# Altium schematic sheet PWR.SchDoc(PWR)
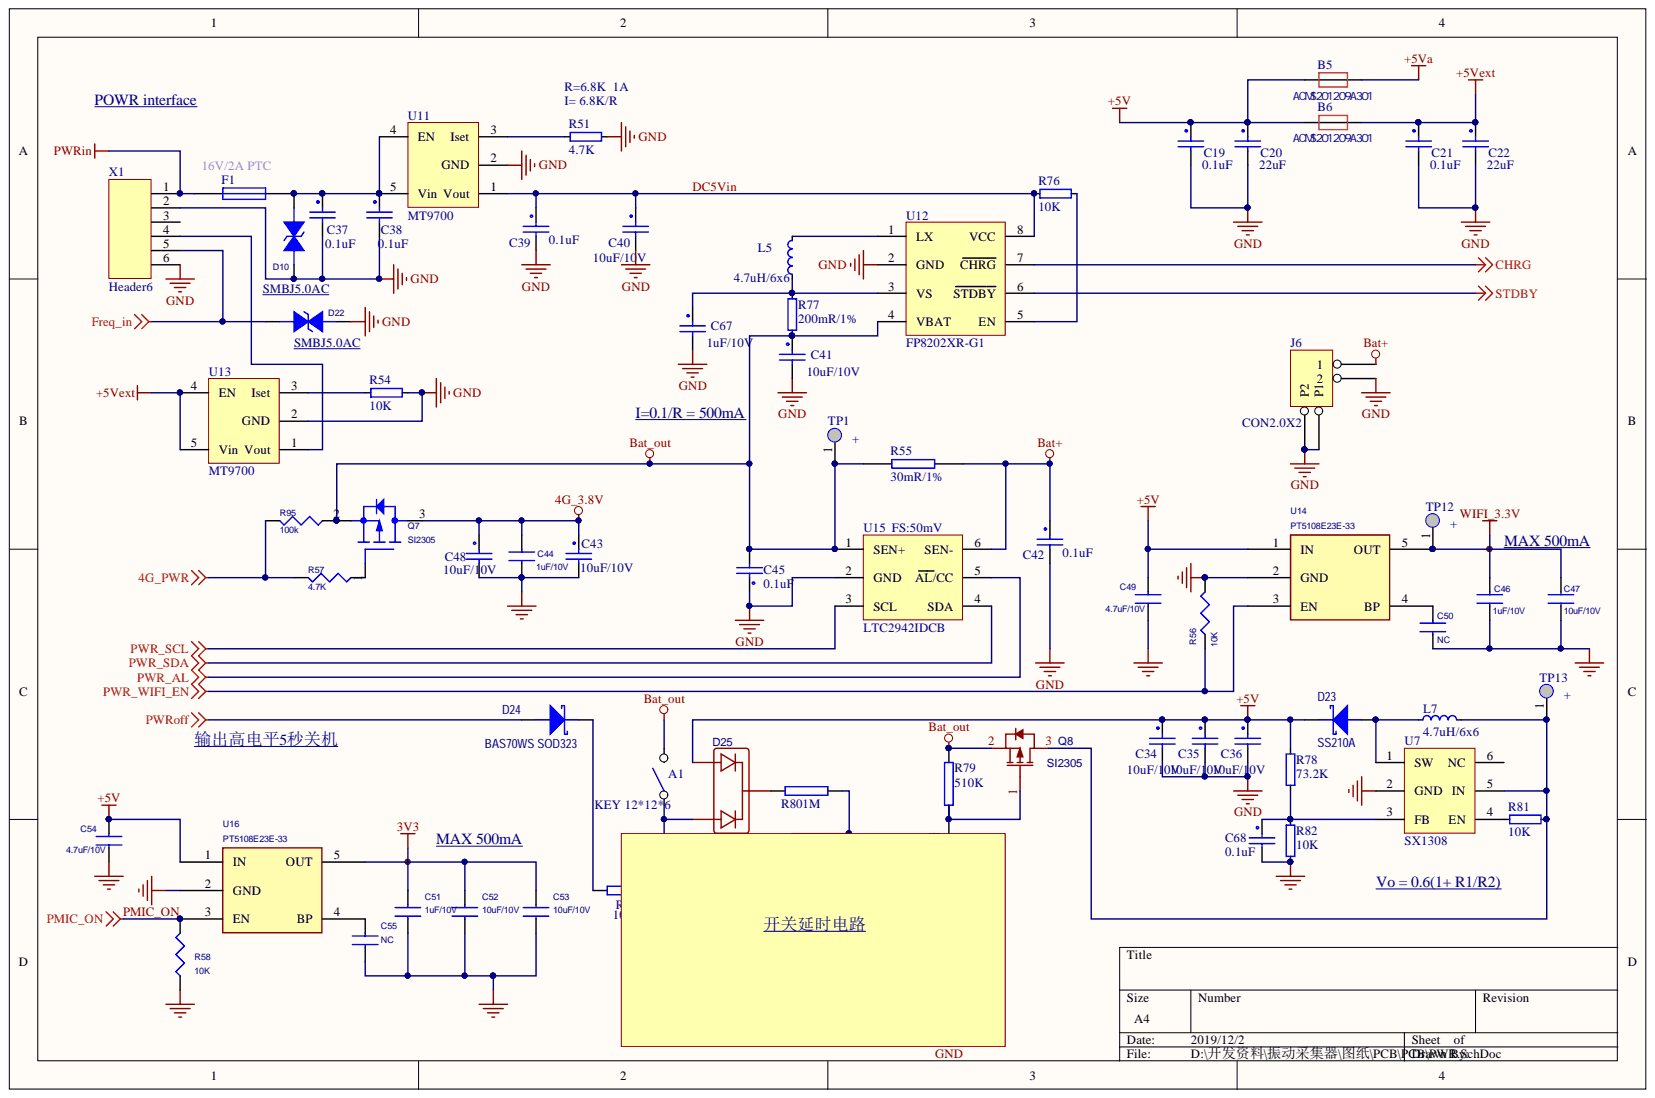
[diagram p0: PWR.SchDoc]

## Parts
A1  KEY 12*12*6  [BT12x12*6]
B5  ACMS201209A301  [0805_R]
B6  ACMS201209A301  [0805_R]
C19  0.1uF  [0402_C]
C20  22uF  [0603_C]
C21  0.1uF  [0402_C]
C22  22uF  [0603_C]
C34  10uF/10V  [0603_C]
C35  10uF/10V  [0603_C]
C36  10uF/10V  [0603_C]
C37  0.1uF  [0402_C]
C38  0.1uF  [0402_C]
C39  0.1uF  [0402_C]
C40  10uF/10V  [0603_C]
C41  10uF/10V  [0603_C]
C42  0.1uF  [0402_C]
C43  10uF/10V  [0603_C]
C44  1uF/10V  [0402_C]
C45  0.1uF  [0402_C]
C46  1uF/10V  [0402_C]
C47  10uF/10V  [0603_C]
C48  10uF/10V  [0603_C]
C49  4.7uF/10V  [0402_C]
C50  NC  [0402_C]
C51  1uF/10V  [0402_C]
C52  10uF/10V  [0603_C]
C53  10uF/10V  [0603_C]
C54  4.7uF/10V  [0402_C]
C55  NC  [0402_C]
C67  1uF/10V  [0402_C]
C68  0.1uF  [0402_C]
C69  0.1uF  [0402_C]
C70  1uF/10V  [0402_C]
D10  SMBJ5.0AC  [DO214]
D22  SMBJ5.0AC  [DO214]
D23  SS210A  [DO214AA]
D24  BAS70WS SOD323  [SOD-323]
D25  BAS70W-05  [SOT-23]
F1  16V/2A PTC  [1812]
J6  CON2.0X2  [HY20LB-2P]
L5  4.7uH/6x6  [0402]
L7  4.7uH/6x6  [0402]
Q7  SI2305  [SOT23]
Q8  SI2305  [SOT-23]
Q9  8050  [SOT-23(SOT-23-3)]
Q10  8050  [SOT-23(SOT-23-3)]
R51  4.7K  [0402]
R53  1M  [0402]
R54  10K  [0402]
R55  30mR/1%  [0805_R]
R56  10K  [0402_R]
R57  4.7K  [0603_C]
R58  10K  [0402_R]
R76  10K  [0402]
R77  200mR/1%  [0402]
R78  73.2K  [0402]
R79  510K  [0402_R]
R80  1M  [0402_R]  (value not drawn)
R81  10K  [0402]
R82  10K  [0402]
R83  510K  [0402_R]
R84  100K  [0402_R]
R85  100K  [0402_R]
R86  100K  [0402_R]
R87  1M  [0402]
R95  100k  [0603_C]
TP1  +  [TP]
TP12  +  [TP]
TP13  +  [TP]
U7  SX1308
U11  MT9700  [SOT23-5]
U12  FP8202XR-G1  [SO-8-A]
U13  MT9700  [SOT23-5]
U14  PT5108E23E-33  [SOT23-5]
U15  LTC2942IDCB  [DFN-6]
U16  PT5108E23E-33  [SOT23-5]
X1  Header6  [ZH15LB-6P]

## Nets
3V3: C51.1 C52.1 C53.1 U16.5(OUT)
4G_3.8V: C43.1 C44.1 C48.1 Q7.3
4G_PWR: R57.1 R95.1
+5V: B5.1 B6.1 C19.1 C20.1 C34.1 C35.1 C36.1 C49.1 C54.1 D23.C D25.1 R78.1 U14.1(IN) U16.1(IN)
+5VA: B5.2
+5VEXT: B6.2 C21.1 C22.1 U13.4(EN) U13.5(Vin)
BAT+: C42.1 J6.1 R55.2 U15.6(SEN-)
BAT_OUT: A1.2 C41.1 C45.2 Q7.2 Q8.2 R55.1 R77.2 R79.1 R95.2 TP1.1 U12.4(VBAT) U15.1(SEN+)
CHRG: U12.7(CHRG)
FREQ_IN: D22.1 X1.5
GND: C19.2 C20.2 C21.2 C22.2 C34.2 C35.2 C36.2 C37.2 C38.2 C39.2 C40.2 C41.2 C42.2 C43.2 C44.2 C45.1 C46.2 C47.2 C48.2 C49.2 C50.1 C51.2 C52.2 C53.2 C54.2 C55.1 C67.2 C68.2 C69.2 C70.2 D10.2 D22.2 J6.2 J6.P1 J6.P2 R51.1 R53.1 R54.1 R56.2 R58.1 R82.1 R83.2 R86.2 U7.2(GND) U11.2(GND) U12.2(GND) U13.2(GND) U14.2(GND) U15.2(GND) U16.2(GND) X1.2 X1.6
PWR_AL: U15.5(AL/CC)
PWR_SCL: U15.3(SCL)
PWR_SDA: U15.4(SDA)
PWR_WIFI_EN: R56.1 U14.3(EN)
PWRIN: F1.2 X1.1
PWROFF: D24.A
STDBY: U12.6(STDBY)
WIFI_3.3V: C46.1 C47.1 TP12.1 U14.5(OUT)
DC5VIN: C39.1 C40.1 R76.2 U11.1(Vout) U12.8(VCC)
PMIC_ON: R58.2 U16.3(EN)
NetA1_1: A1.1 D25.2 R87.2
NetC37_1: C37.1 C38.1 D10.1 F1.1 U11.4(EN) U11.5(Vin)
NetC50_2: C50.2 U14.4(BP)
NetC55_2: C55.2 U16.4(BP)
NetC67_1: C67.1 L5.1 R77.1 U12.3(VS)
NetC68_1: C68.1 R78.2 R82.2 U7.3(FB)
NetC69_1: C69.1 Q9.1 Q10.3 R80.1 R83.1
NetC70_1: C70.1 R53.2 R84.1 R85.2 R87.1
NetD23_A: D23.A L7.1 U7.1(SW)
NetD24_C: D24.C R84.2
NetD25_3: D25.3 R80.2
NetL5_2: L5.2 U12.1(LX)
NetL7_2: L7.2 Q8.3 R81.1 TP13.1 U7.5(IN)
NetQ7_1: Q7.1 R57.2
NetQ8_1: Q8.1 Q9.3 R79.2
NetQ9_2: Q9.2 Q10.2 R86.1
NetQ10_1: Q10.1 R85.1
NetR51_2: R51.2 U11.3(Iset)
NetR54_2: R54.2 U13.3(Iset)
NetR76_1: R76.1 U12.5(EN)
NetR81_2: R81.2 U7.4(EN)
NetU13_1: U13.1(Vout) X1.4

Not connected: U7 6(NC); X1 3

## Library data of the parts
A1 = SW_SPST_6MM: SPST SWITCH 6MM; MANUFACTURER: TE CONNECTIVITY / ALCOSWITCH; PART: FSM4JSMA; Component Kind: Standard; FARNELL: 3801305; Library Name: Arbury_SCH.SchLib
B5 B6 = Bead: Component Kind: Standard; Library Name: RP3288BV03_20180126-.SCHLIB
C19 C21 C37-C39 C42 C45 C68 C69 = CAP_100N_25V_0402_10%_X5R: MANUFACTURER: TAIYO YUDEN; PART: TMK105BJ104KV-F; Component Kind: Standard; Description: 0.1uF; FARNELL: 1611916; Library Name: Arbury_C.SchLib
C20 C22 C34-C36 C40 C41 C43 C48 C67 C70 = CAP_100N_25V_0402_10%_X5R: 100nF; MANUFACTURER: TAIYO YUDEN; PART: TMK105BJ104KV-F; Component Kind: Standard; FARNELL: 1611916; Library Name: Arbury_C.SchLib
C44 = CAP NP_Dup2: CAP,MLCC,100nF,+/-10%,X7R,6.3V,SMD0402; Component Kind: Standard
C46 C47 C49 C51-C54 = CAP NP_Dup4: RoHS CHIP CAP 4.7uF +10/-10% X5R; Component Kind: Standard
C50 C55 = CAP NP_Dup4: RoHS CHIP CAP 0.1uF +10/-10% X7R; Component Kind: Standard
D10 D22 = DIODE BI-DIR TRIG: ESD; Component Kind: Standard
D23 D24 = DIO-SCH: Diode schottky; Component Kind: Standard; Library Name: Diodes_cn.SCHLIB
D25 = BAS70W-05: BOM_Manufacturer: CJ; Contributor: LCSC; SMT Type: Extend; BOM_LCSC Assembly: Yes; BOM_Manufacturer Part: BAS70W-05; BOM_Supplier: LCSC; BOM_Supplier Part: C77310; Component Kind: Standard; spicePre: D
F1 = FUSE1: Fuse; Component Kind: Standard
J6 = CON_JST_2MM_2W_SMD_H: 2 PIN 2MM SMT JST  CONNECTOR; PART: S2B-PH-SM4-TB(LF)(SN); Component Kind: Standard; DIGIKEY: 455-1749-1-ND; FARNELL: 9492615; MANUFACTURER: JST; Library Name: Arbury_CON.SchLib
L5 L7 = L0402-3n3: Inductor, 190mA, ?.1nH; Manufacturer: Murata; Manufacturer Part Number: LQP15MN3N3B02D; Artikkelnr Noca: N.A.; Database Table Name: Inductors$; Note: High frequency chip inductor ?%; Value: 3.3nH; Component Kind: Standard; Datasheet: http://search.murata.co.jp/Ceramy/image/img/PDF/ENG/L0075S0084LQP15M.pdf; LatestRevisionDate: 2012-09-19; Library Name: Nordic Passive DB.DbLib; Part Number: L0402-3n3; Pin Count: 2; Published: 2009-05-08; Supplier: Digi-Key; Supplier part number: 490-1130-1-ND; Publisher: Nordic Semiconductor
Q7 = FET P MOS: Component Kind: Standard
Q8 = SI2301_C351397: BOM_Manufacturer: PUOLOP; BOM_Manufacturer Part: SI2301; Contributor: LCSC; BOM_Supplier: LCSC; BOM_Supplier Part: C351397; Component Kind: Standard; spicePre: Q
Q9 Q10 = NPN: NPN Transistor; Component Kind: Standard
R51 R53 R54 R76-R78 R81 R82 R87 = R0402-12k: Resistor, ?%, 0.063W; Artikkelnr Noca: 27049099; Database Table Name: Resistors$; Note: Chip Res 1%; Value: 12k; Component Kind: Standard; LatestRevisionDate: 2009-11-23; Library Name: Nordic Passive DB.DbLib; Part Number: R0402-12k; Pin Count: 2; Published: 2009-05-08; Supplier: Farnell; Supplier part number: 173-8865; Publisher: Nordic Semiconductor
R55 R79 R80 R83-R86 = RES2: Component Kind: Standard
R56 R58 = RESISTOR_Dup2: ROHS CHIP RES 10K 1/16W 5%; Component Kind: Standard
R57 R95 = RESISTOR_1: RES,thick film,47KOhm,+/-5%,1/16W,SMD0402; Component Kind: Standard
TP1 TP12 TP13 = TP: Component Kind: Standard; Library Name: mysch.SchLib
U14 U16 = LDO-PT5108/RT9013: Component Kind: Standard
U15 = LTC2942: Component Kind: Standard; Library Name: RT1052_CORE_P120.lib
X1 = Header6: Header, 5-Pin; Component Kind: Standard; LatestRevisionDate: 17-Jul-2002; LatestRevisionNote: Re-released for DXP Platform.; Library Name: MYSCH.SchLib; Publisher: Altium Limited
U7 = SX1308: Component Kind: Standard; Library Name: 振动采集器.SCHLIB
U11 U13 = MT9700: Component Kind: Standard; Library Name: 振动采集器.SCHLIB
U12 = FP8202XR-G1: Component Kind: Standard; Library Name: 振动采集器.SCHLIB
